# Altium schematic sheet g_l.SchDoc
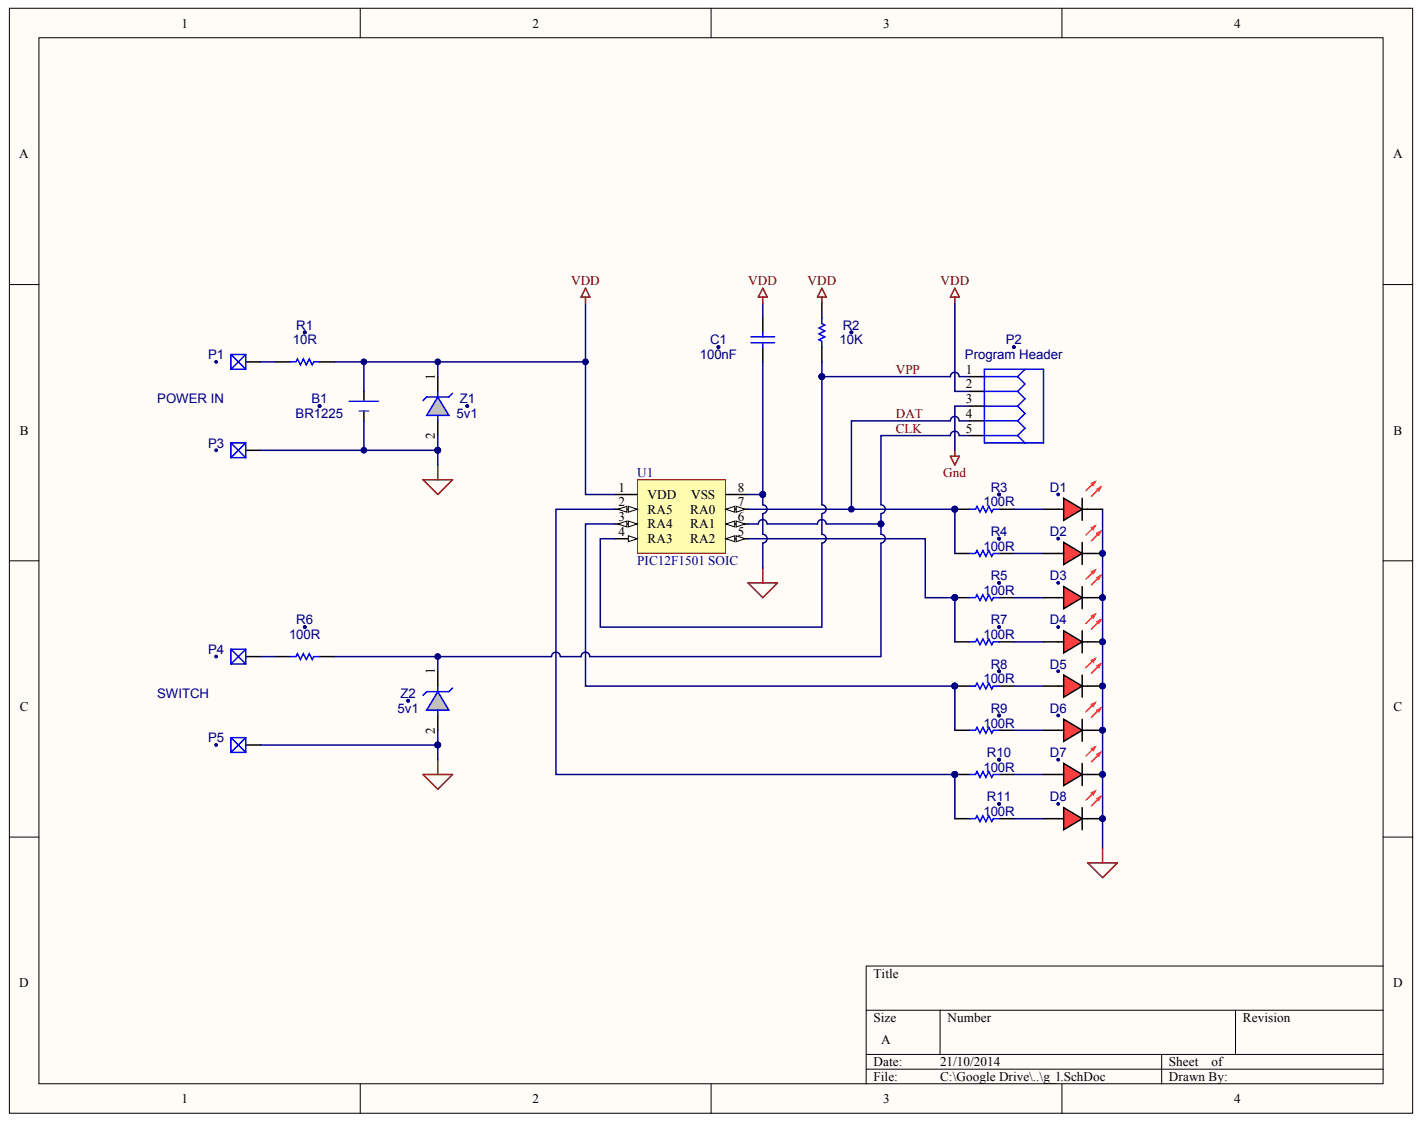
[diagram p0: g_l.SchDoc]

## Parts
B1  BR1225
C1  100nF
D1  Side View  (value not drawn)
D2  Side View  (value not drawn)
D3  Side View  (value not drawn)
D4  Side View  (value not drawn)
D5  Side View  (value not drawn)
D6  Side View  (value not drawn)
D7  Side View  (value not drawn)
D8  Side View  (value not drawn)
P1  HOLE35-70-22GA  (value not drawn)
P2  Program Header
P3  HOLE35-70-22GA  (value not drawn)
P4  HOLE35-70-22GA  (value not drawn)
P5  HOLE35-70-22GA  (value not drawn)
R1  10R
R2  10K
R3  100R
R4  100R
R5  100R
R6  100R
R7  100R
R8  100R
R9  100R
R10  100R
R11  100R
U1  PIC12F1501 SOIC  (value not drawn)
Z1  5v1
Z2  5v1

## Nets
GND: B1.2 C1.1 D1.1 D2.1 D3.1 D4.1 D5.1 D6.1 D7.1 D8.1 P2.3 P3.1 P5.1 U1.8(VSS) Z1.2 Z2.2
VDD: B1.1 C1.2 P2.2 R1.2 R2.1 U1.1(VDD) Z1.1
CLK: P2.5 R6.2 U1.6(RA1) Z2.1
DAT: P2.4 R3.1 R4.1 U1.7(RA0)
VPP: P2.1 R2.2 U1.4(RA3)
NetD1_2: D1.2 R3.2
NetD2_2: D2.2 R4.2
NetD3_2: D3.2 R5.2
NetD4_2: D4.2 R7.2
NetD5_2: D5.2 R8.2
NetD6_2: D6.2 R9.2
NetD7_2: D7.2 R10.2
NetD8_2: D8.2 R11.2
NetP1_1: P1.1 R1.1
NetP4_1: P4.1 R6.1
NetR5_1: R5.1 R7.1 U1.5(RA2)
NetR8_1: R8.1 R9.1 U1.3(RA4)
NetR10_1: R10.1 R11.1 U1.2(RA5)

## Library data of the parts
B1 = (no symbol name): AS P/N: BHSD-1225-SM
C1 = (no symbol name): Case-Metric: 1608; Tolerance: ±10%; Case-EIA: 0603; AS P/N: ASC_100N_0603; Value: 100nF
P2 = (no symbol name): AS P/N: GRPB051VWVN-RC; ModifyDate: 12/09/2009 15:24:36
R1 = (no symbol name): Case-Metric: 1608; Tolerance: 1 %; Power: 0.1 W; Case-EIA: 0603; Value: 10.00 Ohm; Technology: SMT
R2 = (no symbol name): Value: 10000.00 Ohm; Tolerance: 1 %; Case-EIA: 0603; Case-Metric: 1608; AS P/N: ASR_10K0_0603
R3-R11 = (no symbol name): Value: 100.00 Ohm; Case-EIA: 0603; Tolerance: 1 %; Power: 0.1 W; Case-Metric: 1608; AS P/N: ASR_100R_0603; Technology: SMT
U1 = (no symbol name): AS P/N: PIC12F1501_SOIC
Z1 Z2 = (no symbol name): AS P/N: MM3Z5V1
D1-D8 P1 P3-P5 = (no symbol name): ModifyDate: 11/19/2010 06:25:10; Value: *
